# Altium schematic sheet Xberry 2 Power Supply.SchDoc
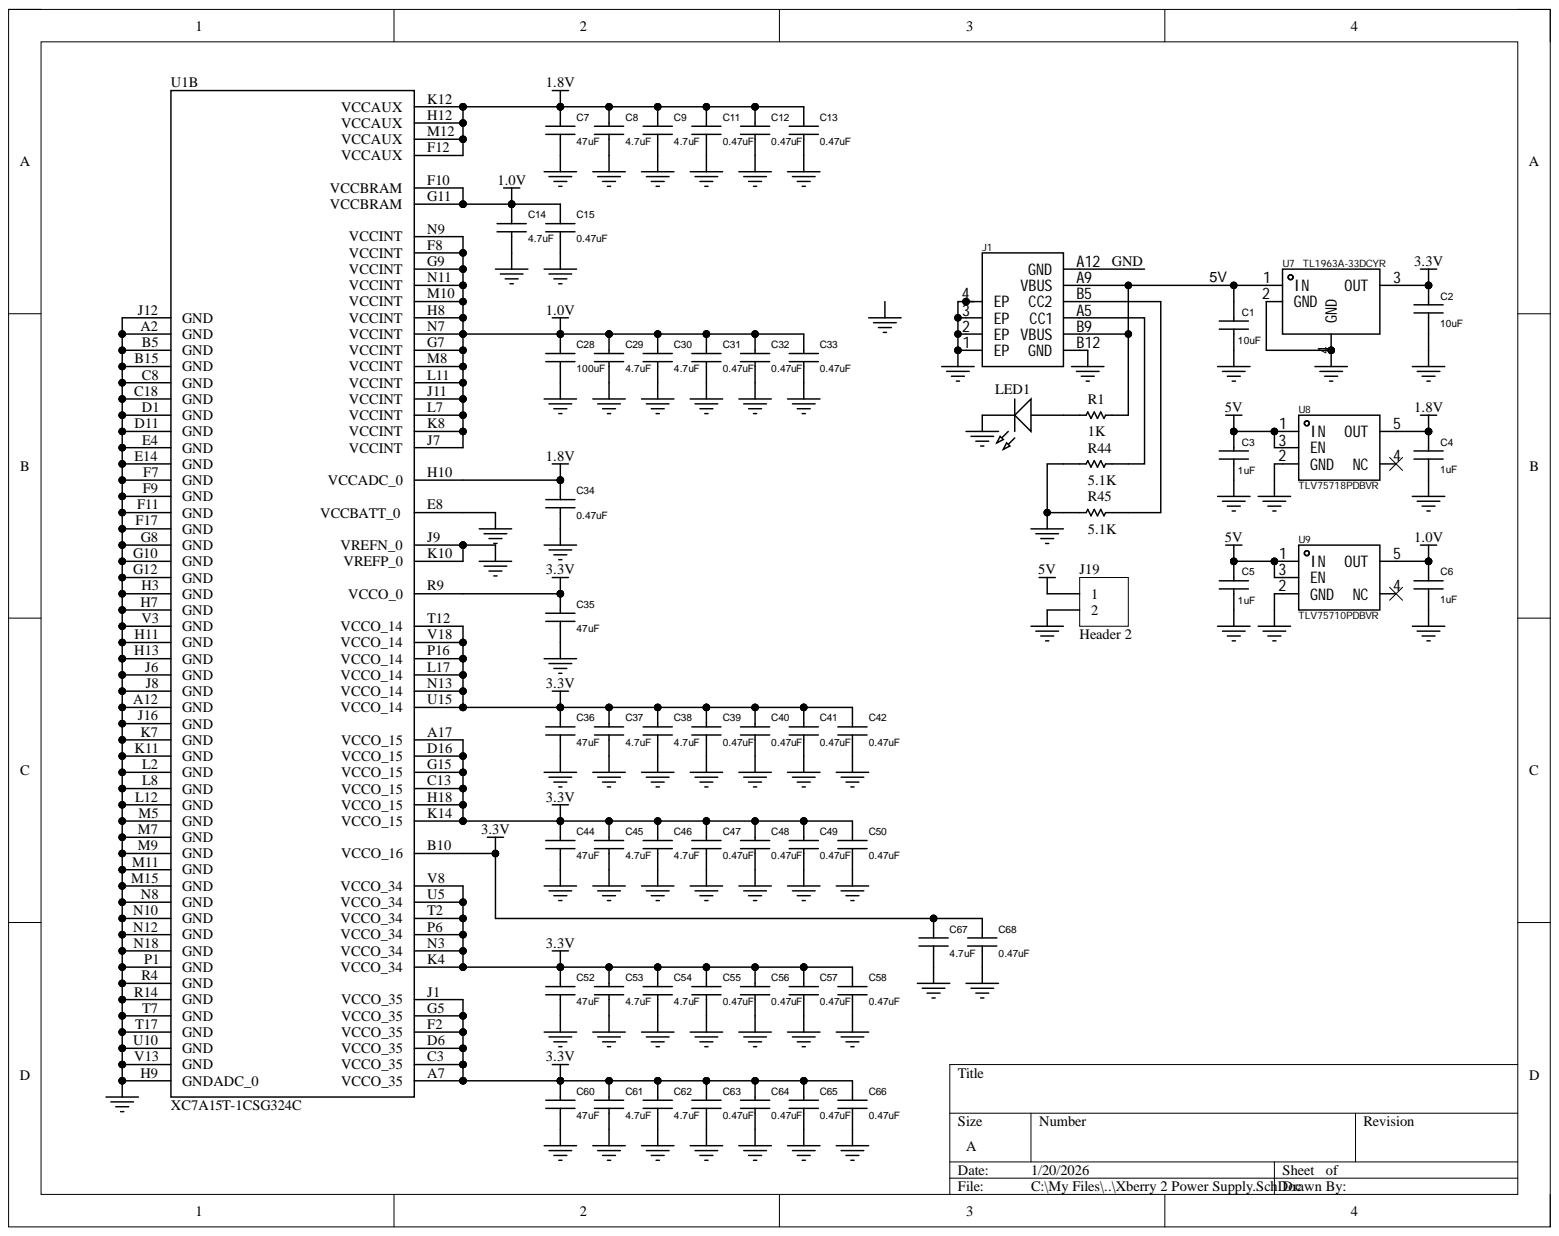
[diagram p0: Xberry 2 Power Supply.SchDoc]

## Parts
C1  10uF  [CAP: 0603]
C2  10uF  [CAP: 0603]
C3  1uF  [CAP: 0603]
C4  1uF  [CAP: 0603]
C5  1uF  [CAP: 0603]
C6  1uF  [CAP: 0603]
C7  47uF  [CAP: 0603]
C8  4.7uF  [CAP: 0402]
C9  4.7uF  [CAP: 0402]
C11  0.47uF  [CAP: 0402]
C12  0.47uF  [CAP: 0402]
C13  0.47uF  [CAP: 0402]
C14  4.7uF  [CAP: 0402]
C15  0.47uF  [CAP: 0402]
C28  100uF  [CAP: 0805]
C29  4.7uF  [CAP: 0402]
C30  4.7uF  [CAP: 0402]
C31  0.47uF  [CAP: 0402]
C32  0.47uF  [CAP: 0402]
C33  0.47uF  [CAP: 0402]
C34  0.47uF  [CAP: 0402]
C35  47uF  [CAP: 0603]
C36  47uF  [CAP: 0603]
C37  4.7uF  [CAP: 0402]
C38  4.7uF  [CAP: 0402]
C39  0.47uF  [CAP: 0402]
C40  0.47uF  [CAP: 0402]
C41  0.47uF  [CAP: 0402]
C42  0.47uF  [CAP: 0402]
C44  47uF  [CAP: 0603]
C45  4.7uF  [CAP: 0402]
C46  4.7uF  [CAP: 0402]
C47  0.47uF  [CAP: 0402]
C48  0.47uF  [CAP: 0402]
C49  0.47uF  [CAP: 0402]
C50  0.47uF  [CAP: 0402]
C52  47uF  [CAP: 0603]
C53  4.7uF  [CAP: 0402]
C54  4.7uF  [CAP: 0402]
C55  0.47uF  [CAP: 0402]
C56  0.47uF  [CAP: 0402]
C57  0.47uF  [CAP: 0402]
C58  0.47uF  [CAP: 0402]
C60  47uF  [CAP: 0603]
C61  4.7uF  [CAP: 0402]
C62  4.7uF  [CAP: 0402]
C63  0.47uF  [CAP: 0402]
C64  0.47uF  [CAP: 0402]
C65  0.47uF  [CAP: 0402]
C66  0.47uF  [CAP: 0402]
C67  4.7uF  [CAP: 0402]
C68  0.47uF  [CAP: 0402]
J1  KH-TYPE-C-6P  [USB-C-TYPE-C-31-M-16]  (value not drawn)
J19  Header 2  [HDR1X2]
LED1  LED0  [SM/LED_0603_21]  (value not drawn)
R1  1K  [RES: 0603]
R44  5.1K  [RES: 0603]
R45  5.1K  [RES: 0603]
U1  XC7A15T-1CSG324C  [CSG324_XIL]  (part B)
U7  TL1963A-33DCYR  [SOT-223_L6.5-W3.5-P2.30-LS7.0-BR]
U8  TLV75718PDBVR  Output Voltage: 1.8V  [SOT-23-5_L2.9-W1.6-P0.95-LS2.8-BL]
U9  TLV75710PDBVR  [SOT-23-5_L2.9-W1.6-P0.95-LS2.8-BL]

## Nets
1.0V: C6.1 C14.1 C15.1 C28.1 C29.1 C30.1 C31.1 C32.1 C33.1 U1.F8(VCCINT) U1.F10(VCCBRAM) U1.G7(VCCINT) U1.G9(VCCINT) U1.G11(VCCBRAM) U1.H8(VCCINT) U1.J7(VCCINT) U1.J11(VCCINT) U1.K8(VCCINT) U1.L7(VCCINT) U1.L11(VCCINT) U1.M8(VCCINT) U1.M10(VCCINT) U1.N7(VCCINT) U1.N9(VCCINT) U1.N11(VCCINT) U9.5(OUT)
1.8V: C4.1 C7.1 C8.1 C9.1 C11.1 C12.1 C13.1 C34.1 U1.F12(VCCAUX) U1.H10(VCCADC_0) U1.H12(VCCAUX) U1.K12(VCCAUX) U1.M12(VCCAUX) U8.5(OUT)
3.3V: C2.1 C35.1 C36.1 C37.1 C38.1 C39.1 C40.1 C41.1 C42.1 C44.1 C45.1 C46.1 C47.1 C48.1 C49.1 C50.1 C52.1 C53.1 C54.1 C55.1 C56.1 C57.1 C58.1 C60.1 C61.1 C62.1 C63.1 C64.1 C65.1 C66.1 C67.1 C68.1 U1.A7(VCCO_35) U1.A17(VCCO_15) U1.B10(VCCO_16) U1.C3(VCCO_35) U1.C13(VCCO_15) U1.D6(VCCO_35) U1.D16(VCCO_15) U1.F2(VCCO_35) U1.G5(VCCO_35) U1.G15(VCCO_15) U1.H18(VCCO_15) U1.J1(VCCO_35) U1.K4(VCCO_34) U1.K14(VCCO_15) U1.L17(VCCO_14) U1.N3(VCCO_34) U1.N13(VCCO_14) U1.P6(VCCO_34) U1.P16(VCCO_14) U1.R9(VCCO_0) U1.T2(VCCO_34) U1.T12(VCCO_14) U1.U5(VCCO_34) U1.U15(VCCO_14) U1.V8(VCCO_34) U1.V18(VCCO_14) U7.3(OUT)
5V: C1.1 C3.1 C5.1 J1.A9(VBUS) J1.B9(VBUS) J19.1 R1.1 U7.1(IN) U8.1(IN) U8.3(EN) U9.1(IN) U9.3(EN)
GND: C1.2 C2.2 C3.2 C4.2 C5.2 C6.2 C7.2 C8.2 C9.2 C11.2 C12.2 C13.2 C14.2 C15.2 C28.2 C29.2 C30.2 C31.2 C32.2 C33.2 C34.2 C35.2 C36.2 C37.2 C38.2 C39.2 C40.2 C41.2 C42.2 C44.2 C45.2 C46.2 C47.2 C48.2 C49.2 C50.2 C52.2 C53.2 C54.2 C55.2 C56.2 C57.2 C58.2 C60.2 C61.2 C62.2 C63.2 C64.2 C65.2 C66.2 C67.2 C68.2 J1.1(EP) J1.2(EP) J1.3(EP) J1.4(EP) J1.A12(GND) J1.B12(GND) J19.2 LED1.2 R44.2 R45.2 U1.A2(GND) U1.A12(GND) U1.B5(GND) U1.B15(GND) U1.C8(GND) U1.C18(GND) U1.D1(GND) U1.D11(GND) U1.E4(GND) U1.E8(VCCBATT_0) U1.E14(GND) U1.F7(GND) U1.F9(GND) U1.F11(GND) U1.F17(GND) U1.G8(GND) U1.G10(GND) U1.G12(GND) U1.H3(GND) U1.H7(GND) U1.H9(GNDADC_0) U1.H11(GND) U1.H13(GND) U1.J6(GND) U1.J8(GND) U1.J9(VREFN_0) U1.J12(GND) U1.J16(GND) U1.K7(GND) U1.K10(VREFP_0) U1.K11(GND) U1.L2(GND) U1.L8(GND) U1.L12(GND) U1.M5(GND) U1.M7(GND) U1.M9(GND) U1.M11(GND) U1.M15(GND) U1.N8(GND) U1.N10(GND) U1.N12(GND) U1.N18(GND) U1.P1(GND) U1.R4(GND) U1.R14(GND) U1.T7(GND) U1.T17(GND) U1.U10(GND) U1.V3(GND) U1.V13(GND) U7.2(GND) U7.4(GND) U8.2(GND) U9.2(GND)
NetJ1_A5: J1.A5(CC1) R44.1
NetJ1_B5: J1.B5(CC2) R45.1
NetLED1_1: LED1.1 R1.2

Not connected: U8 4(NC); U9 4(NC)

## Library data of the parts
C1 = CAP NP: COMPFIXED: NO; COMPGROUP: 0; COMPHEIGHT: 0.000; COMPLOC: [340.000,620.000]; COMPSIDE: TOP; FPLIST: SM/C_0805_VIA,SM/C_0805_VIA1,SM/C_0603,SM/C_0805; COMPKEY: NO; COMPLOCKED: NO; Component Kind: Standard; COMPROT: 0; DECOUPLER: NO; MEMORY: NO
C2 = CAP NP: COMPFIXED: NO; COMPGROUP: 0; COMPHEIGHT: 0.000; COMPLOC: [250.000,620.000]; COMPROT: 180; COMPSIDE: TOP; FPLIST: SM/C_0805,SM/C_0603_VIA,SM/C_0603,SM/C_0805_VIA; COMPKEY: NO; COMPLOCKED: NO; Component Kind: Standard; DECOUPLER: NO; MEMORY: NO
C3 C5 = CAP NP: COMPFIXED: NO; COMPGROUP: 0; COMPHEIGHT: 0.000; COMPLOC: [1485.000,1660.000]; COMPSIDE: BOT; FPLIST: SM/C_0805_VIA2,SM/C_0805_VIA,SM/C_0805_VIA1,SM/C_0603,SM/C_0805; COMPKEY: NO; COMPLOCKED: NO; Component Kind: Standard; COMPROT: 0; DECOUPLER: NO; MEMORY: NO
C4 C6 = CAP NP: COMPFIXED: NO; COMPGROUP: 0; COMPHEIGHT: 0.000; COMPLOC: [1575.000,1660.000]; COMPROT: 180; COMPSIDE: BOT; FPLIST: SM/C_0805_VIA2,SM/C_0805,SM/C_0603_VIA,SM/C_0603,SM/C_0805_VIA; COMPKEY: NO; COMPLOCKED: NO; Component Kind: Standard; DECOUPLER: NO; MEMORY: NO
C7 C35 C36 C44 C52 C60 = CAP NP: COMPFIXED: NO; COMPGROUP: 0; COMPHEIGHT: 0.000; COMPLOC: [1415.000,1500.000]; COMPROT: 180; COMPSIDE: BOT; FPLIST: SM/C_0805,SM/C_0603; COMPKEY: NO; COMPLOCKED: NO; Component Kind: Standard; DECOUPLER: NO; MEMORY: NO
C8 C37 C45 C53 C61 = CAP NP: COMPFIXED: NO; COMPGROUP: 0; COMPHEIGHT: 0.000; COMPLOC: [1375.000,795.000]; COMPSIDE: BOT; FPLIST: SM/C_0805,SM/C_0603; COMPKEY: NO; COMPLOCKED: NO; Component Kind: Standard; COMPROT: 0; DECOUPLER: NO; MEMORY: NO
C9 C14 C30 C38 C46 C54 C62 C67 = CAP NP: COMPFIXED: NO; COMPGROUP: 0; COMPHEIGHT: 0.000; COMPLOC: [1695.000,1500.000]; COMPROT: 180; COMPSIDE: BOT; FPLIST: SM/C_0603_VIA1,SM/C_0603,SM/C_0805,SM/C_0603_VIA; COMPKEY: NO; COMPLOCKED: NO; Component Kind: Standard; DECOUPLER: NO; MEMORY: NO
C11 C32 C40 C48 C56 C64 = CAP NP: COMPFIXED: NO; COMPGROUP: 0; COMPHEIGHT: 0.000; COMPLOC: [1820.000,1500.000]; COMPROT: 180; COMPSIDE: BOT; FPLIST: SM/C_0603_VIA,SM/C_0805,SM/C_0603,SM/C_0603_VIA1; COMPKEY: NO; COMPLOCKED: NO; Component Kind: Standard; DECOUPLER: NO; MEMORY: NO
C12 C13 C28 C29 C33 C34 C41 C42 C49 C50 C57 C58 C65 C66 = CAP NP: COMPFIXED: NO; COMPGROUP: 0; COMPHEIGHT: 0.000; COMPLOC: [1255.000,1345.000]; COMPROT: 90; COMPSIDE: BOT; FPLIST: SM/C_0805,SM/C_0603; COMPKEY: NO; COMPLOCKED: NO; Component Kind: Standard; DECOUPLER: NO; MEMORY: NO
C15 C31 C39 C47 C55 C63 C68 = CAP NP: COMPFIXED: NO; COMPGROUP: 0; COMPHEIGHT: 0.000; COMPLOC: [1695.000,1325.000]; COMPSIDE: BOT; FPLIST: SM/C_0603,SM/C_0805,SM/C_0603_VIA; COMPKEY: NO; COMPLOCKED: NO; Component Kind: Standard; COMPROT: 0; DECOUPLER: NO; MEMORY: NO
J1 = USB-KH-TYPE-C-6P_C709355: Manufacturer: kinghelm(金航标); Add into BOM: yes; Connector Type: Type-C; Current Rating - Power (Max): 5A; Gender: Female; Number of Contacts: 6P; Number of Ports: 1; Component Kind: Standard; Convert to PCB: yes; Datasheet: https://atta.szlcsc.com/upload/public/pdf/source/20200722/C709355_1195801B3DE07627BE17E403420D896E.pdf; Device: KH-TYPE-C-6P_C709355; JLCPCB Part Class: Extended Part; LCSC Part Name: Type-C 母 卧贴; Manufacturer Part: KH-TYPE-C-6P; Mounting Style: 卧贴; Operating Temperature Range: -25℃~+85℃; PCB3D: TYPE-C-SMD_6P-L8.9-W6.8-H3.2-P1.00; Standard: -; Supplier: LCSC; Supplier Footprint: SMD; Supplier Part: C709355; Symbol: KH-TYPE-C-6P; Library Name: Retro Computer.SchLib
J19 = Header 2: Header, 2-Pin; Component Kind: Standard; LatestRevisionDate: 17-Jul-2002; LatestRevisionNote: Re-released for DXP Platform.; Library Name: Miscellaneous Connectors.IntLib; Signal Integrity: Connector; Publisher: Altium Limited
LED1 = LED0: Typical INFRARED GaAs LED; Component Kind: Standard; LatestRevisionDate: 08-Jul-2005; LatestRevisionNote: Mech Layer 1 removed.; Library Name: Miscellaneous Devices.IntLib; PackageDescription: LED; 2 Leads; PackageReference: LED-0; Published: 8-Jun-2000; Simulation: LED0; Publisher: Altium Limited
R1 R44 R45 = Res1: Resistor; Component Kind: Standard; LatestRevisionDate: 17-Jul-2002; LatestRevisionNote: Re-released for DXP Platform.; Library Name: Miscellaneous Devices.IntLib; PackageReference: AXIAL-0.3; Published: 8-Jun-2000; Signal Integrity: Res; Simulation: RESISTOR; Publisher: Altium Limited
  R1: Value: 1K
  R44 R45: Value: 5.1K
U1 = XC7A15T-1CSG324C: Manufacturer_Part_Number: XC7A100T-1CSG324C; Mfr_Name: Xilinx Inc; Component Kind: Standard; Library Name: Artix-7 FPGA.SchLib; RefDes: RefDes; Type: DEV; Copyright: Copyright (C) 2024 Ultra Librarian. All rights reserved.
U7 = TL1963A-33DCYR: Manufacturer: TI(德州仪器); Add into BOM: yes; Dropout Voltage: 550mV@(1.5A); Maximum Input Voltage: 20V; Number of Outputs: 1; Output Current: 1.5A; Output Polarity: Positive electrode; Output Type: Fixed; Output Voltage: 3.3V; Power Supply Rejection Ratio (PSRR): 63dB@(120Hz); standby current: 1mA; Component Kind: Standard; Convert to PCB: yes; Datasheet: https://www.ti.com/cn/lit/gpn/tl1963a; Device: TL1963A-33DCYR; Feature: ESD Protection；Low ESR；Thermal Protection(TSD)；Overcurrent Protection(OCP); JLCPCB Part Class: Extended Part; LCSC Part Name: 具有反向电流和反向电压保护功能的 1.5A、20V 超低压降稳压器; Library Name: Voltage Regulator.SchLib; Manufacturer Part: TL1963A-33DCYR; Operating Temperature: -40℃~+125℃; Supplier: LCSC; Supplier Footprint: SOT-223; Supplier Part: C181262; Symbol: TL1963A-33DCYR
U8 = TLV75718PDBVR: Manufacturer: TI(德州仪器); Add into BOM: yes; Dropout Voltage: 800mV@(1A); Maximum Input Voltage: 5.5V; Number of Outputs: 1; Output Current: 1A; Output Polarity: Positive electrode; Output Type: Fixed; Component Kind: Standard; Convert to PCB: yes; Datasheet: https://www.ti.com/cn/lit/gpn/tlv757p; Device: TLV75718PDBVR; Feature: ESD Protection；Surge Protection；Low ESR；Thermal Protection(TSD)；Under-voltage Lockout；Overcurrent Protection(OCP)；With ability; JLCPCB Part Class: Extended Part; LCSC Part Name: 具有使能功能的 1A、低 IQ、高精度、低压降稳压器; Library Name: Voltage Regulator.SchLib; Manufacturer Part: TLV75718PDBVR; Operating Temperature: -40℃~+125℃@(Tj); PCB3D: SOT-23-5_L2.9-W1.6-H1.1-LS2.8-P0.95; Supplier: LCSC; Supplier Footprint: SOT-23-5; Supplier Part: C507270; Symbol: TLV75718PDBVR
U9 = TLV75710PDBVR: Manufacturer: TI(德州仪器); Add into BOM: yes; Dropout Voltage: 1.2V@(1A); Maximum Input Voltage: 5.5V; Number of Outputs: 1; Output Current: 1A; Output Polarity: Positive electrode; Output Type: Fixed; Output Voltage: 1V; Component Kind: Standard; Convert to PCB: yes; Datasheet: https://www.ti.com/cn/lit/gpn/tlv757p; Device: TLV75710PDBVR; JLCPCB Part Class: Extended Part; LCSC Part Name: 具有使能功能的 1A、低 IQ、高精度、低压降稳压器; Library Name: Voltage Regulator.SchLib; Manufacturer Part: TLV75710PDBVR; Operating Temperature: -40℃~+125℃@(Tj); Supplier: LCSC; Supplier Footprint: SOT-23-5; Supplier Part: C2865385; Symbol: TLV75710PDBVR
